# Altium schematic sheet POWER.SchDoc
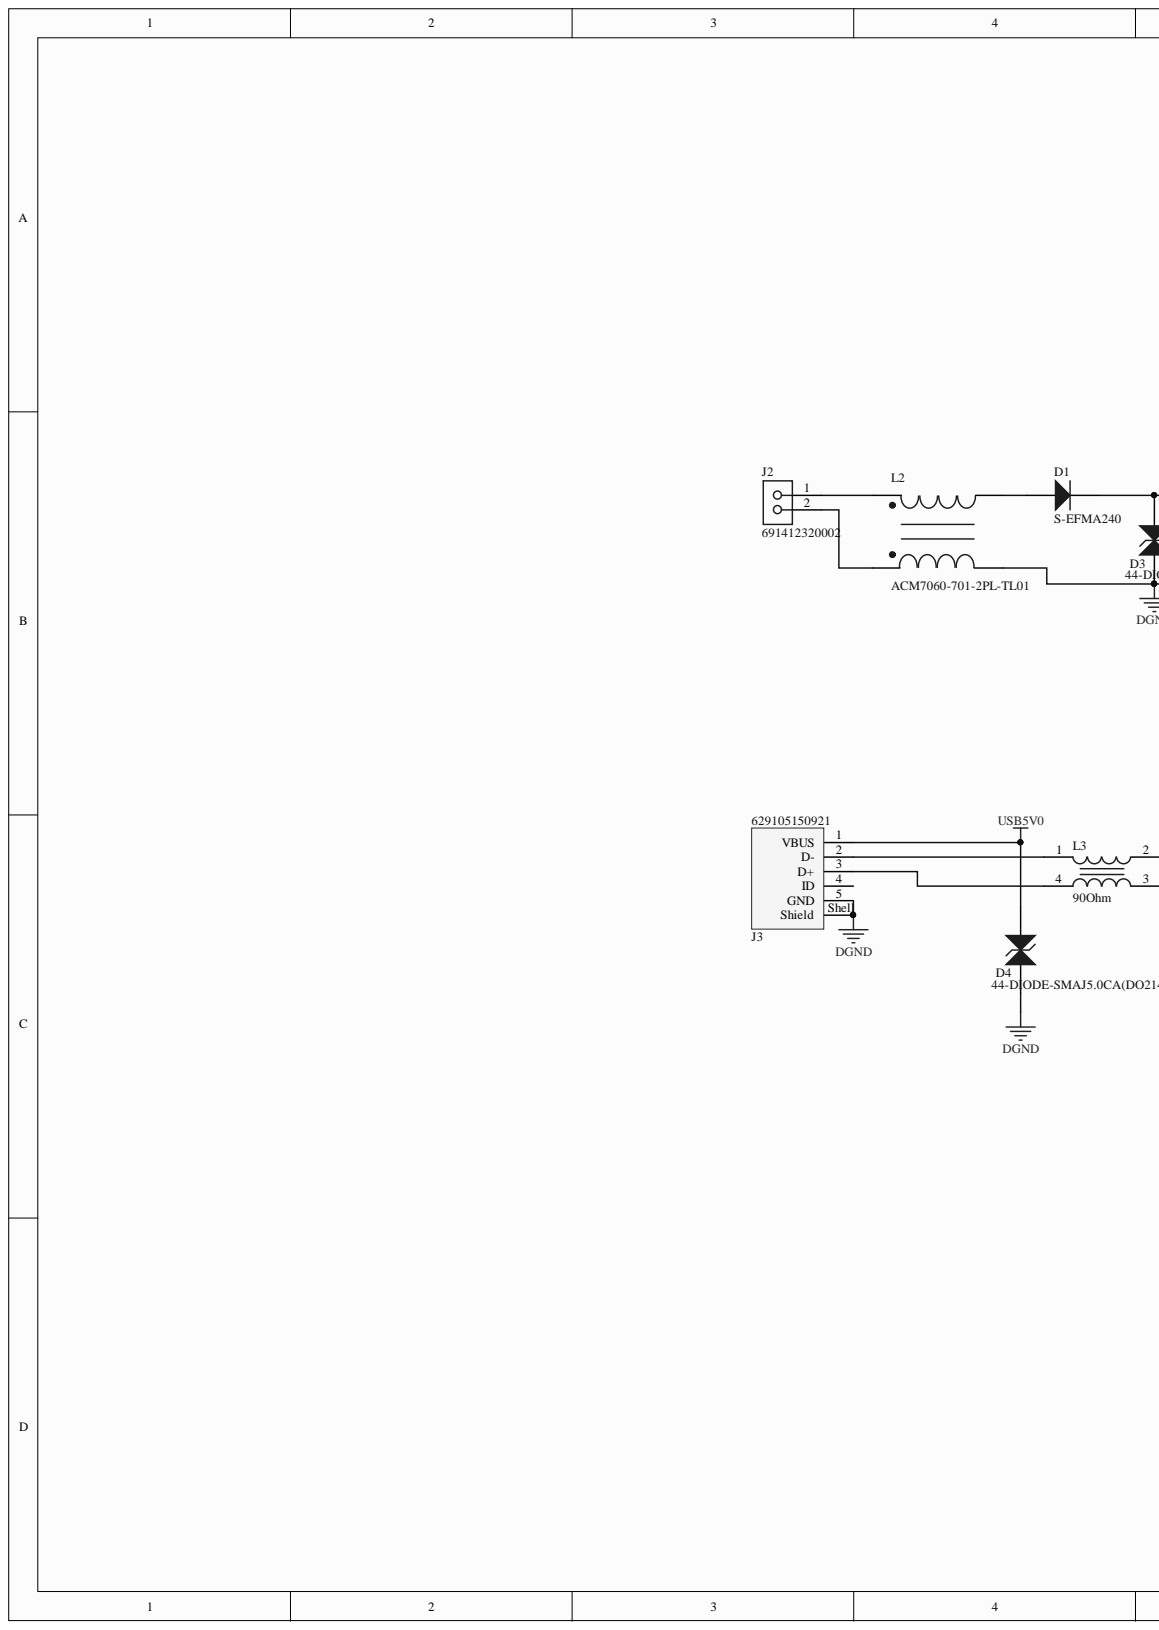
[diagram p0: POWER.SchDoc, left]
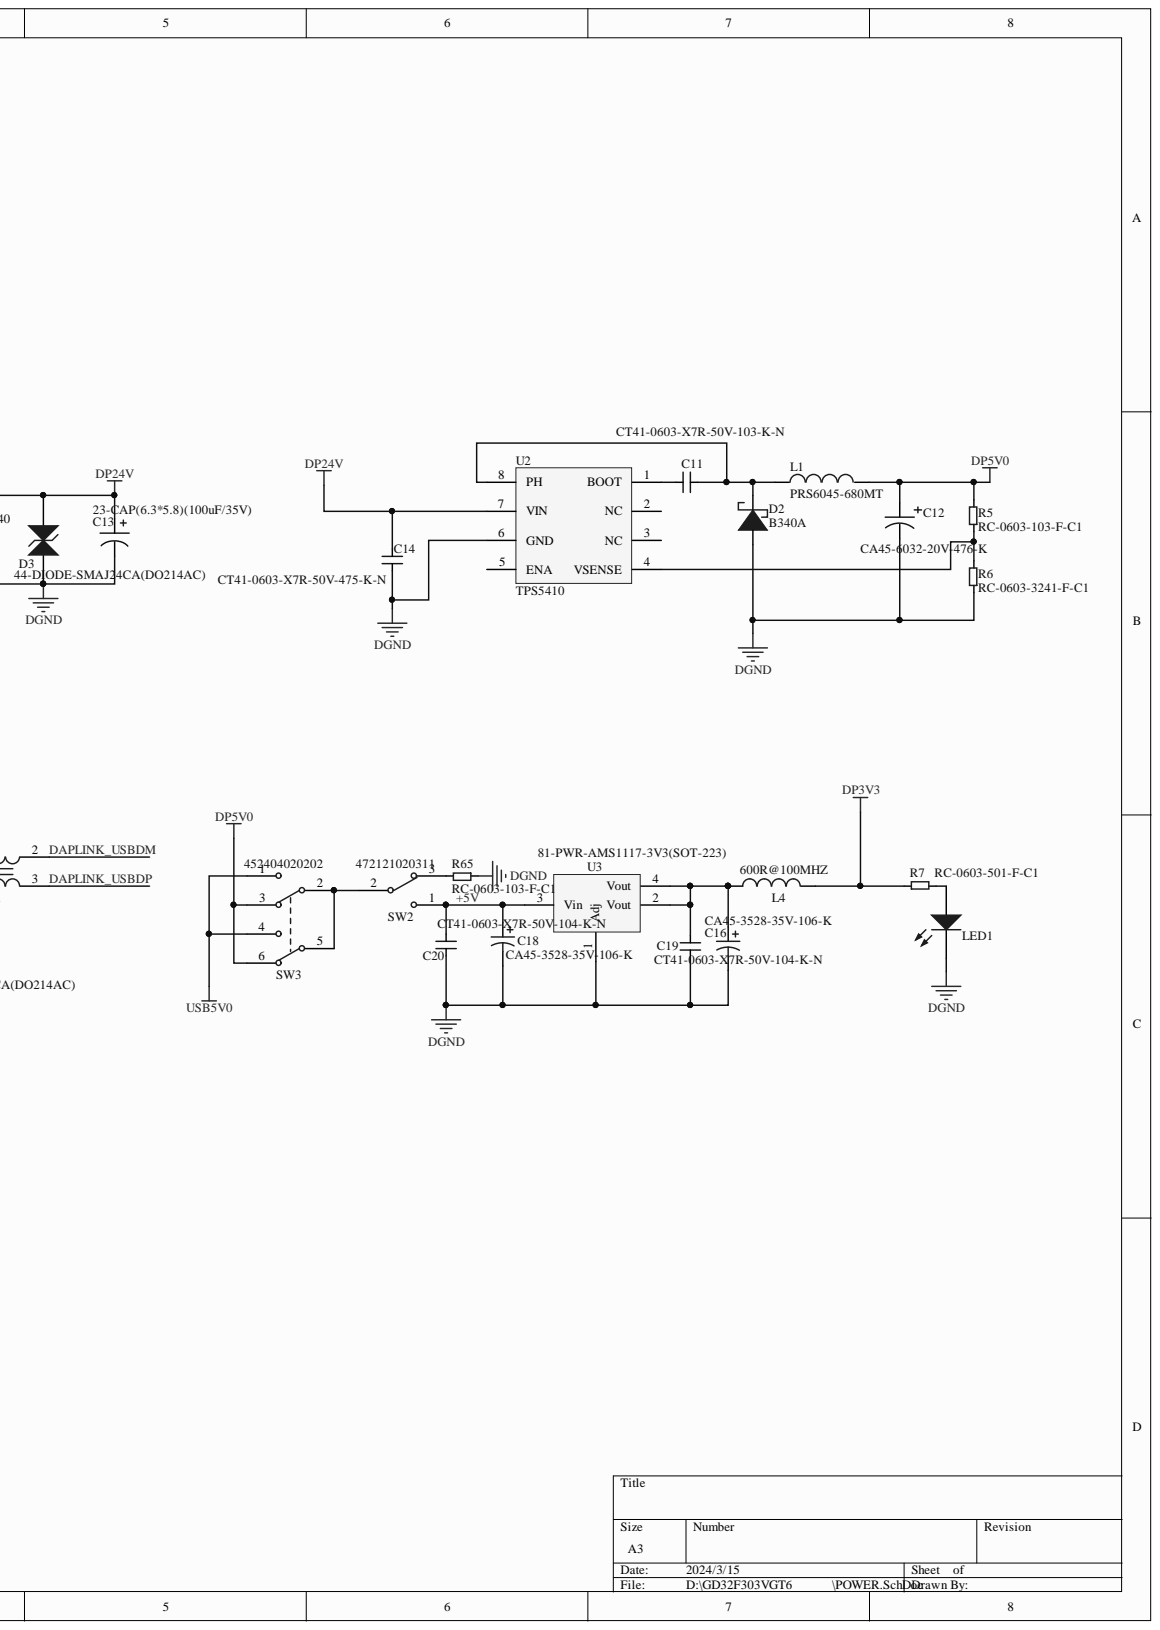
[diagram p1: POWER.SchDoc, right]

## Parts
C11  CT41-0603-X7R-50V-103-K-N  [0805C]
C12  CA45-6032-20V-476-K  [C6032]
C13  23-CAP(6.3*5.8)(100uF/35V)  [23-CAP(6.3*5.8)]
C14  CT41-0603-X7R-50V-475-K-N  [0805C]
C16  CA45-3528-35V-106-K  [21-CAP(3528)]
C18  CA45-3528-35V-106-K  [21-CAP(3528)]
C19  CT41-0603-X7R-50V-104-K-N  [0805C]
C20  CT41-0603-X7R-50V-104-K-N  [0805C]
D1  S-EFMA240  [40-DIODE-1N4007(DO-214AC)]
D2  B340A  [44-DIODE-SAMJ24A(DO214AC)]
D3  44-DIODE-SMAJ24CA(DO214AC)  [44-DIODE-SAMJ24A(DO214AC)]
D4  44-DIODE-SMAJ5.0CA(DO214AC)  [44-DIODE-SAMJ24A(DO214AC)]
J2  691412320002  [691412320002]
J3  629105150921  [629105150921]
L1  PRS6045-680MT  [PRS6045-680MT]
L2  ACM7060-701-2PL-TL01  [ACM7060]
L3  90Ohm  [WE-CNSW_0603]
L4  600R@100MHZ  [30-INDUCTOR(0805)]
LED1  LED2  [LED0805]  (value not drawn)
R5  RC-0603-103-F-C1  [0805R]
R6  RC-0603-3241-F-C1  [0805R]
R7  RC-0603-501-F-C1  [0805R]
R65  RC-0603-103-F-C1  [0805R]
SW2  472121020311  [472121020311]
SW3  452404020202  [452404020202]
U2  TPS5410  [SOIC-8]
U3  81-PWR-AMS1117-3V3(SOT-223)  [81-PWR-AMS1117-3V3(SOT-223)]

## Nets
DGND: C12.2 C13.2 C14.1 C16.2 C18.2 C19.1 C20.1 D2.1 D3.1 D4.1 J3.5(GND) J3.Shell(Shield) L2.4 LED1.K R6.1 R65.1 U2.6(GND) U3.1(Adj)
DP3V3: L4.1 R7.1
DP5V0: C12.1 L1.2 R5.2 SW3.3 SW3.6
DP24V: C13.1 C14.2 D1.2 D3.2 U2.7(VIN)
USB5V0: D4.2 J3.1(VBUS) SW3.1 SW3.4
+5V: C18.1 C20.2 SW2.1 U3.3(Vin)
DAPLINK_USBDM: L3.2
DAPLINK_USBDP: L3.3
NetC11_1: C11.1 D2.2 L1.1 U2.8(PH)
NetC11_2: C11.2 U2.1(BOOT)
NetC16_1: C16.1 C19.2 L4.2 U3.2(Vout) U3.4(Vout)
NetD1_1: D1.1 L2.3
NetJ2_1: J2.1 L2.2
NetJ2_2: J2.2 L2.1
NetJ3_2: J3.2(D-) L3.1
NetJ3_3: J3.3(D+) L3.4
NetLED1_A: LED1.A R7.2
NetR5_1: R5.1 R6.2 U2.4(VSENSE)
NetR65_2: R65.2 SW2.3
NetSW2_2: SW2.2 SW3.2 SW3.5

Not connected: J3 4(ID); U2 2(NC) 3(NC) 5(ENA)

## Library data of the parts
C11 C14 C19 C20 = CAP(0603): Component Kind: Standard; Library Name: ming.SchLib
C12 = CA45-6032-?: Component Kind: Standard; Library Name: DBDGDJ.SchLib
C13 = 23-CAP(6.3*5.8): Component Kind: Standard; Library Name: NING.SchLib
C16 C18 = Cap Pol1: Polarized Capacitor (Radial); Component Kind: Standard; LatestRevisionDate: 17-Jul-2002; LatestRevisionNote: Re-released for DXP Platform.; Library Name: Miscellaneous Devices.IntLib; PackageDescription: Polarized Capacitor (Radial); 2 Leads; PackageReference: RB7.6-15; Published: 8-Jun-2000; Publisher: Altium Limited
D1 = 40-DIODE-1N4007(DO-214AC): Component Kind: Standard; Library Name: NING.SchLib
D3 D4 = 44-DIODE-SAMJ24A(DO214AC): Component Kind: Standard; Library Name: NING.SchLib
J2 = 691412320002: WR-TBL Series 4123 45° Entry, THT, pitch 3.81mm, 2p; Manufacturer: Wurth Elektronik; Modularity: No; Rated Current: 4A; Working Voltage: 300V; Application: Screwless; Category: Terminal Blocks; Component Kind: Standard; ComponentLink1Description: Website Link; ComponentLink1URL: https://www.we-online.com/redexpert/article/691412320002?ad; ComponentLink2Description: Datasheet Link; ComponentLink2URL: https://www.we-online.com/redexpert/spec/691412320002?ad; Library Name: SCHLIB20230425.SchLib; Manufacturer Part Number: 691412320002; Match Code: WR-TBL; Mount: Through Hole; Number of Pins: 2; Number of Rows: 1; Operating Temperature Max: 120°C; Operating Temperature Min: -30°C; Orientation: 45°; Packaging: Box; Pitch: 3.81mm
J3 = 629105150921: WR-COM Micro USB 2.0 Type AB Horizontal; Manufacturer: Wurth Elektronik; Gender: Receptacle; Interface Type: Type AB; Match Code: WR-USB; Number of Ports: 1; Rated Current: 1.8A; Working Voltage: 30V (AC); Application: Micro USB 2.0; Category: Input/Output Connectors; Component Kind: Standard; ComponentLink1Description: Website Link; ComponentLink1URL: https://www.we-online.com/redexpert/article/629105150921?ad; ComponentLink2Description: Datasheet Link; ComponentLink2URL: https://www.we-online.com/redexpert/spec/629105150921?ad; Library Name: SCHLIB20230425.SchLib; Manufacturer Part Number: 629105150921; Mount: Surface Mount; Number of Pins: 5; Operating Temperature Max: 85°C; Operating Temperature Min: -40°C; Orientation: Horizontal; Packaging: Tape and Reel
L3 = 744230900: WE-CNSW SMT Common Mode Line Filter, size 0603, 90Ohm, 0.134uH, 50V; Manufacturer: Wurth Elektronik; Case/Size Code: 0603; DC Resistance Max: 0.145Ohm; Insulation Test Voltage: 125VAC; Rated Current: 0.55A; Winding Style: bifiliar; Category: Common Mode Chokes; Component Kind: Standard; ComponentLink1Description: Manufacturer Link; ComponentLink1URL: https://www.we-online.com/redexpert/article/744230900?ad; ComponentLink2Description: Datasheet; ComponentLink2URL: https://www.we-online.com/redexpert/spec/744230900?ad; Height: 1.1mm; Impedance @100MHz: 90Ohm; Inductance: 0.134uH; Length: 1.6mm; Library Name: SCHLIB20230425.SchLib; Manufacturer Part Number: 744230900; Match Code: WE-CNSW; Mount: Surface Mount; Operating Temperature Max: 125°C; Operating Temperature Min: -40°C; Packaging: Tape and Reel; Rated Voltage: 50V; Width: 0.85mm
L4 = INDUCTOR: : 600R@100M/200MA; Component Kind: Standard; Library Name: mickey.IntLib
LED1 = LED2: Typical RED, GREEN, YELLOW, AMBER GaAs LED; Manufacturer: Generic Components; Class I: Optoelectronic; Value: 红色; Class II: LED; Component Kind: Standard; Published: 8-Jun-2000; Revision: July-2002: Re-released for DXP Platform.; Publisher: Altium Limited
R5-R7 R65 = 10-RES(0805): Component Kind: Standard; Library Name: NING.SchLib
SW2 = 472121020311: WS-TOTV Ø 6.35mm Panel Cut-Out Vertical Operation Toggle Switch, SPDT, ON-ON, 30V, 1A; Manufacturer: Wurth Elektronik; Actuator Length: 7.3mm; Bushing Length: 7.1mm; Insulation Resistance: 1000MOhm; Withstanding Voltage: 500VAC; Category: Toggle Switches; Circuit: SPDT; Component Kind: Standard; ComponentLink1Description: Website Link; ComponentLink1URL: https://www.we-online.com/redexpert/article/472121020311?ad; ComponentLink2Description: Datasheet Link; ComponentLink2URL: https://www.we-online.com/redexpert/spec/472121020311?ad; Height: 23.2mm; Length: 12.7mm; Library Name: SCHLIB20230425.SchLib; Manufacturer Part Number: 472121020311; Match Code: WS-TOTV; Mount: Through Hole; Operating Temperature Max: 85°C; Operating Temperature Min: -40°C; Packaging: Tray; Rated Current: 1A; Rated Voltage (DC): 30V; Switching Function: ON-ON; Width: 6.6mm
SW3 = 452404020202: WS-SLTV THT Mini Slide Switch, Same Side Connection, DPDT, 6VDC, 300mA, 450g; Manufacturer: Wurth Elektronik; Category: Slide Switches; Circuit: DPDT; Component Kind: Standard; ComponentLink1Description: Website Link; ComponentLink1URL: https://www.we-online.com/redexpert/article/452404020202?ad; ComponentLink2Description: Datasheet Link; ComponentLink2URL: https://www.we-online.com/redexpert/spec/452404020202?ad; Contact Material: Copper Alloy; Contact Plating: Silver; Height: 5.5mm; Length: 9mm; Library Name: SCHLIB20230425.SchLib; Manufacturer Part Number: 452404020202; Match Code: WS-SLTV; Mount: Through Hole; Operating Temperature Max: 85°C; Operating Temperature Min: -40°C; Operation Force: 450g; Packaging: Bulk; Rated Current: 300mA; Rated Voltage: 6VDC; Terminal Material: Copper Alloy; Terminal Plating: Silver; Width: 3.8mm
D2 = B340A: Component Kind: Standard; Library Name: DBDGDJ.SchLib
L1 = PRS6045-680MT: Component Kind: Standard; Library Name: DBDGDJ.SchLib
L2 = ACM7060-701-2PL-TL01: Component Kind: Standard; Library Name: DBDGDJ.SchLib
U2 = TPS5410: Component Kind: Standard; Library Name: DBDGDJ.SchLib
U3 = 81-PWR-AMS1117-3V3(SOT-223): Component Kind: Standard; Library Name: NING.SchLib
